# Altium schematic sheet Schematic Prints("All Documents",Physical)
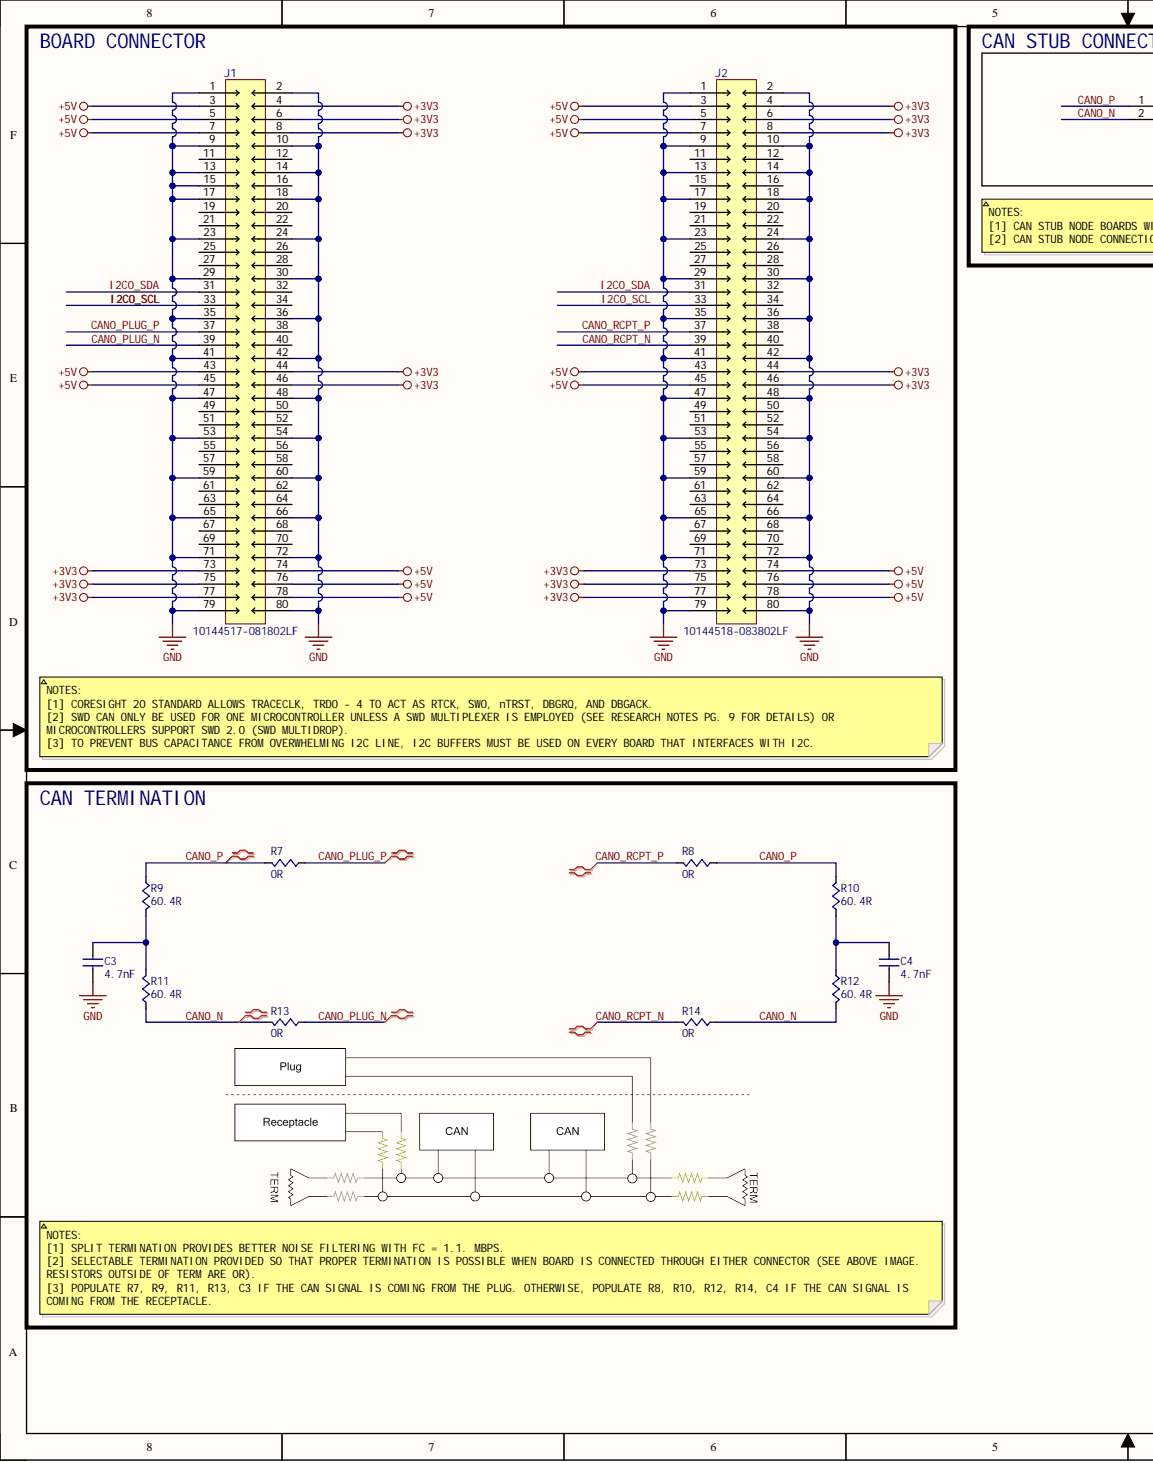
[diagram p0: Schematic Prints("All Documents",Physical), left]
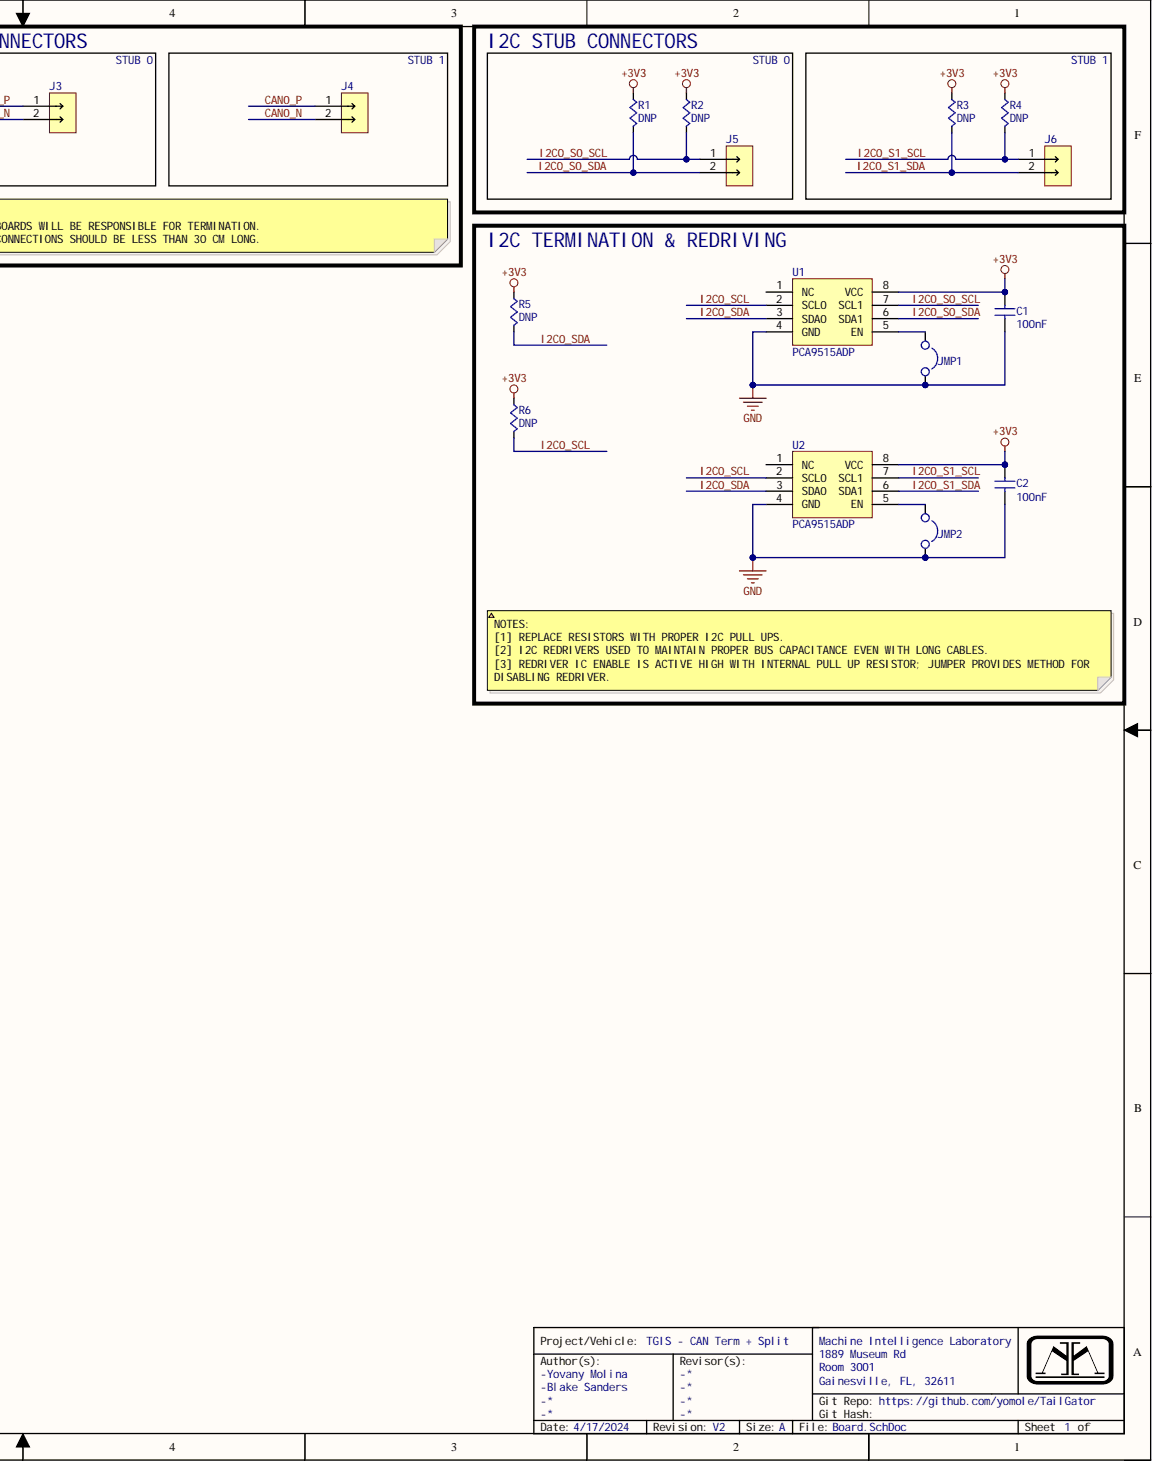
[diagram p1: Schematic Prints("All Documents",Physical), right]

## Parts
C1  100nF  [CAPC0603]
C2  100nF  [CAPC0603]
C3  4.7nF  [CAPC0603]
C4  4.7nF  [CAPC0603]
J1  10144517-081802LF  [AMPHENOL_10144517-081802LF]
J2  10144518-083802LF  [AMPHENOL_10144518-083802LF]
J3  0353630260  [MOLEX-HDR-1X2-SHERLOCK-HORZ]  (value not drawn)
J4  0353630260  [MOLEX-HDR-1X2-SHERLOCK-HORZ]  (value not drawn)
J5  0353630260  [MOLEX-HDR-1X2-SHERLOCK-HORZ]  (value not drawn)
J6  0353630260  [MOLEX-HDR-1X2-SHERLOCK-HORZ]  (value not drawn)
JMP1  2 Pin Header  [2PinJumper]  (value not drawn)  (no BOM)
JMP2  2 Pin Header  [2PinJumper]  (value not drawn)  (no BOM)
R1  DNP  [RES0603]
R2  DNP  [RES0603]
R3  DNP  [RES0603]
R4  DNP  [RES0603]
R5  DNP  [RES0603]
R6  DNP  [RES0603]
R7  0R  [RES0603]
R8  0R  [RES0603]
R9  60.4R  [RES0603]
R10  60.4R  [RES0603]
R11  60.4R  [RES0603]
R12  60.4R  [RES0603]
R13  0R  [RES0603]
R14  0R  [RES0603]
U1  PCA9515ADP  [SOP65P490X110-8N]
U2  PCA9515ADP  [SOP65P490X110-8N]

## Nets
+3V3: C1.2 C2.2 J1.4 J1.6 J1.8 J1.44 J1.46 J1.73 J1.75 J1.77 J2.4 J2.6 J2.8 J2.44 J2.46 J2.73 J2.75 J2.77 R1.2 R2.2 R3.2 R4.2 R5.2 R6.2 U1.8(VCC) U2.8(VCC)
+5V: J1.3 J1.5 J1.7 J1.43 J1.45 J1.74 J1.76 J1.78 J2.3 J2.5 J2.7 J2.43 J2.45 J2.74 J2.76 J2.78
GND: C1.1 C2.1 C3.2 C4.2 J1.1 J1.2 J1.9 J1.10 J1.13 J1.14 J1.15 J1.17 J1.18 J1.23 J1.24 J1.29 J1.30 J1.35 J1.36 J1.41 J1.42 J1.47 J1.48 J1.53 J1.54 J1.59 J1.60 J1.65 J1.66 J1.71 J1.72 J1.79 J1.80 J2.1 J2.2 J2.9 J2.10 J2.13 J2.14 J2.17 J2.18 J2.23 J2.24 J2.29 J2.30 J2.35 J2.36 J2.41 J2.42 J2.47 J2.48 J2.53 J2.54 J2.59 J2.60 J2.65 J2.66 J2.71 J2.72 J2.79 J2.80 JMP1.2 JMP2.2 U1.4(GND) U2.4(GND)
CAN0_N: J3.2 J4.2 R11.2 R12.2 R13.1 R14.2
CAN0_P: J3.1 J4.1 R7.1 R8.2 R9.2 R10.2
CAN0_PLUG_N: J1.39 R13.2
CAN0_PLUG_P: J1.37 R7.2
CAN0_RCPT_N: J2.39 R14.1
CAN0_RCPT_P: J2.37 R8.1
I2C0_S0_SCL: J5.1 R2.1 U1.7(SCL1)
I2C0_S0_SDA: J5.2 R1.1 U1.6(SDA1)
I2C0_S1_SCL: J6.1 R4.1 U2.7(SCL1)
I2C0_S1_SDA: J6.2 R3.1 U2.6(SDA1)
I2C0_SCL: J1.33 J2.33 R6.1 U1.2(SCL0) U2.2(SCL0)
I2C0_SDA: J1.31 J2.31 R5.1 U1.3(SDA0) U2.3(SDA0)
NetC3_1: C3.1 R9.1 R11.1
NetC4_1: C4.1 R10.1 R12.1
NetJ1_11: J1.11
NetJ1_12: J1.12
NetJ1_16: J1.16
NetJ1_19: J1.19
NetJ1_20: J1.20
NetJ1_21: J1.21
NetJ1_22: J1.22
NetJ1_25: J1.25
NetJ1_26: J1.26
NetJ1_27: J1.27
NetJ1_28: J1.28
NetJ1_32: J1.32
NetJ1_34: J1.34
NetJ1_38: J1.38
NetJ1_40: J1.40
NetJ1_49: J1.49
NetJ1_50: J1.50
NetJ1_51: J1.51
NetJ1_52: J1.52
NetJ1_55: J1.55
NetJ1_56: J1.56
NetJ1_57: J1.57
NetJ1_58: J1.58
NetJ1_61: J1.61
NetJ1_62: J1.62
NetJ1_63: J1.63
NetJ1_64: J1.64
NetJ1_67: J1.67
NetJ1_68: J1.68
NetJ1_69: J1.69
NetJ1_70: J1.70
NetJ2_11: J2.11
NetJ2_12: J2.12
NetJ2_15: J2.15
NetJ2_16: J2.16
NetJ2_19: J2.19
NetJ2_20: J2.20
NetJ2_21: J2.21
NetJ2_22: J2.22
NetJ2_25: J2.25
NetJ2_26: J2.26
NetJ2_27: J2.27
NetJ2_28: J2.28
NetJ2_32: J2.32
NetJ2_34: J2.34
NetJ2_38: J2.38
NetJ2_40: J2.40
NetJ2_49: J2.49
NetJ2_50: J2.50
NetJ2_51: J2.51
NetJ2_52: J2.52
NetJ2_55: J2.55
NetJ2_56: J2.56
NetJ2_57: J2.57
NetJ2_58: J2.58
NetJ2_61: J2.61
NetJ2_62: J2.62
NetJ2_63: J2.63
NetJ2_64: J2.64
NetJ2_67: J2.67
NetJ2_68: J2.68
NetJ2_69: J2.69
NetJ2_70: J2.70
NetJMP1_1: JMP1.1 U1.5(EN)
NetJMP2_1: JMP2.1 U2.5(EN)
NetU1_1: U1.1(NC)
NetU2_1: U2.1(NC)

## Library data of the parts
C1 C2 = CC0603KRX7R7BB104: Ceramic Capacitor, Multilayer, Cera; Manufacturer: Yageo; Database Table Name: CAPACITORS; Multilayer: Yes; Rated DC Voltage (URdc): 16V; Temperature Characteristics Code: X7R; Tolerance  (fill in as +-): 10%; Capacitor Type: Ceramic; Case/Package: 0603; Category: Ceramic Capacitors; Component Kind: Standard; ComponentLink1Description: https://datasheet.ciiva.com:443/pdf; ComponentLink1URL: https://datasheet.ciiva.com:443/pdf; ComponentLink2Description: https://datasheet.ciiva.com/11786/0; ComponentLink2URL: https://datasheet.ciiva.com/11786/0; ComponentLink3Description: https://datasheet.ciiva.com/8033/70; ComponentLink3URL: https://datasheet.ciiva.com/8033/70; ComponentLink4Description: https://datasheet.ciiva.com/7978/14; ComponentLink4URL: https://datasheet.ciiva.com/7978/14; ComponentLink5Description: https://datasheet.ciiva.com/28450/1; ComponentLink5URL: https://datasheet.ciiva.com/28450/1; ComponentLink6Description: https://datasheet.ciiva.com/46430/1; ComponentLink6URL: https://datasheet.ciiva.com/46430/1; ComponentLink7Description: https://datasheet.ciiva.com/144/mlc; ComponentLink7URL: https://datasheet.ciiva.com/144/mlc; ComponentLink8Description: https://datasheet.ciiva.com/144/mlc; ComponentLink8URL: https://datasheet.ciiva.com/144/mlc; ComponentLink9Description: https://datasheet.ciiva.com/144/mlc; ComponentLink9URL: https://datasheet.ciiva.com/144/mlc; ComponentLink10Description: https://datasheet.ciiva.com/8033/17; ComponentLink10URL: https://datasheet.ciiva.com/8033/17; ComponentLink11Description: https://datasheet.ciiva.com/13395/u; ComponentLink11URL: https://datasheet.ciiva.com/13395/u; ComponentLink12Description: https://datasheet.ciiva.com/11464/u; ComponentLink12URL: https://datasheet.ciiva.com/11464/u; ComponentLink13Description: https://datasheet.ciiva.com/15165/g; ComponentLink13URL: https://datasheet.ciiva.com/15165/g; ComponentLink14Description: https://datasheet.ciiva.com:443/pdfs/VipMasterIC/IC/YAGO/YAGO-S-A0003557223; ComponentLink14URL: https://datasheet.ciiva.com:443/pdfs/VipMasterIC/IC/YAGO/YAGO-S-A0003557223; ComponentLink15Description: https://datasheet.ciiva.com/11786/0900766b80d84f1d-11786077.pdf?src-supplie; ComponentLink15URL: https://datasheet.ciiva.com/11786/0900766b80d84f1d-11786077.pdf?src-supplie; ComponentLink16Description: https://datasheet.ciiva.com/8033/70765-8033799.pdf?src-supplier=Element14; ComponentLink16URL: https://datasheet.ciiva.com/8033/70765-8033799.pdf?src-supplier=Element14; ComponentLink17Description: https://datasheet.ciiva.com/7978/1489800-7978141.pdf?src-supplier=Element14; ComponentLink17URL: https://datasheet.ciiva.com/7978/1489800-7978141.pdf?src-supplier=Element14; ComponentLink18URL: https://datasheet.ciiva.com/28450/1489800-28450552.pdf?src-supplier=Element; Dielectric Material: Ceramic; Height: 0.8mm; JESD-609 Code: e3; Length: 1.6mm; Library Name: Parts.DbLib; Manufacturer Part Number: CC0603KRX7R7BB104; Max Operating Temperature: 125°C; Min Operating Temperature: -55°C; Mounting Technology: Surface Mount; Package Shape: Rectangular; Package Style: SMTMeter; Packaging: Tape and Reel; Part Number: CC0603KRX7R7BB104; Pins: 2; Pricing 1: 1=0.1, 10=0.042, 50=0.0238, 100=0.0; Reach SVHC Compliant: Yes; RoHS: Yes; RoHS Compliant: Yes; Stock 1: 11347706; Supplier 1: Digikey; Supplier Part Number 1: 311-1088-1-ND; Terminal Finish: Nickel; Termination: Wraparound; Tolerance (fill in as +-): 10%; Value: 100nF; Width: 0.8mm
C3 C4 = CC0603JPX7R9BB472: Ceramic Capacitor, Multilayer, Cera; Manufacturer: Yageo; Database Table Name: CAPACITORS; Multilayer: Yes; Rated DC Voltage (URdc): 50V; Temperature Characteristics Code: X7R; Tolerance  (fill in as +-): 5%; Capacitor Type: Ceramic; Case/Package: 0603; Category: Ceramic Capacitors; Component Kind: Standard; ComponentLink1Description: https://datasheet.ciiva.com:443/pdf; ComponentLink1URL: https://datasheet.ciiva.com:443/pdf; ComponentLink2Description: http://www.mouser.com/ds/2/447/Yage; ComponentLink2URL: http://www.mouser.com/ds/2/447/Yage; Dielectric Material: Ceramic; Height: 0.8mm; JESD-609 Code: e3; Length: 1.6mm; Library Name: Parts.DbLib; Manufacturer Part Number: CC0603JPX7R9BB472; Max Operating Temperature: 125°C; Min Operating Temperature: -55°C; Mounting Technology: Surface Mount; Package Shape: Rectangular; Package Style: SMTMeter; Packaging: Tape and Reel; Part Number: CC0603JPX7R9BB472; Pins: 2; Pricing 1: 1=0.1, 10=0.069, 50=0.0398, 100=0.0; Reach SVHC Compliant: Yes; RoHS: Yes; RoHS Compliant: Yes; Stock 1: 59880; Supplier 1: Digikey; Supplier Part Number 1: 13-CC0603JPX7R9BB472CT-ND; Terminal Finish: Nickel; Termination: Wraparound; Tolerance (fill in as +-): 5%; Value: 4.7nF; Width: 0.8mm
J1 = 10144517-081802LF: CONN RCPT 1 80POS SMD GOLD; System: Connector; Component Kind: Standard; Supplier 1: Digikey; Supplier Part Number 1: 609-5437-1-ND; Library Name: TGIS.IntLib
J2 = 10144518-083802LF: CONN PLUG 3 80POS SMD GOLD; System: Connector; Component Kind: Standard; Supplier 1: Digikey; Supplier Part Number 1: 609-5455-1-ND; Library Name: TGIS.IntLib
J3-J6 = SHERLOCK-1x2-RA: Header, Molex, 2mm Pitch Sherlock Header, Single Row, Horizontal, 2 Circuits, Length 3.3mm,  0353630260; Manufacturer: Molex Inc; Component Kind: Standard; Library Name: Connectors.SchLib; Manufacturer Part Number: 0353630260; Supplier 1: Digi-Key; Supplier Part Number 1: WM18931-ND
JMP1 JMP2 = Jumper_2Pin: 2 Position Jumper; Library Name: Misc.SchLib
R1-R6 = Resistor_DNP: (DO NOT PLACE) Resistor; Component Kind: Standard; Library Name: DNP.SchLib
R7 R8 R13 R14 = RC0603FR-070RL: Fixed Resistor, Metal Glaze/thick F; Database Table Name: RESISTORS; Power: 100mW; Rated Temperature: 70°C; Case/Package: 0603; Category: Chip SMD Resistors; Component Kind: Standard; ComponentLink1Description: https://datasheet.ciiva.com:443/pdf; ComponentLink1URL: https://datasheet.ciiva.com:443/pdf; ComponentLink2Description: https://datasheet.ciiva.com/11808/0; ComponentLink2URL: https://datasheet.ciiva.com/11808/0; ComponentLink3Description: https://datasheet.ciiva.com/5684/44; ComponentLink3URL: https://datasheet.ciiva.com/5684/44; ComponentLink4Description: https://datasheet.ciiva.com/7983/44; ComponentLink4URL: https://datasheet.ciiva.com/7983/44; ComponentLink5Description: https://datasheet.ciiva.com/22643/1; ComponentLink5URL: https://datasheet.ciiva.com/22643/1; ComponentLink6Description: https://datasheet.ciiva.com/46382/4; ComponentLink6URL: https://datasheet.ciiva.com/46382/4; ComponentLink7Description: https://datasheet.ciiva.com/29721/p; ComponentLink7URL: https://datasheet.ciiva.com/29721/p; ComponentLink8Description: https://datasheet.ciiva.com/29721/p; ComponentLink8URL: https://datasheet.ciiva.com/29721/p; ComponentLink9Description: https://datasheet.ciiva.com/5558/rc; ComponentLink9URL: https://datasheet.ciiva.com/5558/rc; JESD-609 Code: e3; Library Name: Parts.DbLib; Max Operating Temperature: 155°C; Min Operating Temperature: -55°C; Mounting Technology: Surface Mount; Package Shape: Rectangular; Packaging: Tape and Reel; Part Number: RC0603FR-070RL; Pins: 2; Pricing 1: 5000=0.0015, 50000=0.0014, 125000=0; Reach SVHC Compliant: Yes; Resistor Type: FIXED RESISTOR; RoHS Compliant: Yes; Stock 1: 2895000; Technology: METAL GLAZE/THICK FILM; Terminal Finish: Nickel; Termination: Wraparound; Value: 0R
R9-R12 = RC0603FR-0760R4L: Fixed Resistor, Metal Glaze/thick F; Manufacturer: Yageo; Additional Feature: LASER TRIMMABLE; Database Table Name: RESISTORS; Package Height: 0.45mm; Package Length: 1.6mm; Package Width: 0.8mm; Power: 100mW; Rated Temperature: 70°C; Temperature Coefficient: 100ppm/°C; Tolerance: 1%; Working Voltage: 75V; Case/Package: 0603; Category: Chip SMD Resistors; Component Kind: Standard; ComponentLink1Description: https://datasheet.ciiva.com:443/pdf; ComponentLink1URL: https://datasheet.ciiva.com:443/pdf; ComponentLink2Description: https://datasheet.ciiva.com/12558/r; ComponentLink2URL: https://datasheet.ciiva.com/12558/r; Construction: Chip; JESD-609 Code: e3; Library Name: Parts.DbLib; Manufacturer Part Number: RC0603FR-0760R4L; Max Operating Temperature: 155°C; Min Operating Temperature: -55°C; Mounting Technology: Surface Mount; Package Shape: Rectangular; Package Style: SMTMeter; Packaging: Tape and Reel; Part Number: RC0603FR-0760R4L; Pins: 2; Pricing 1: 1=0.1, 10=0.019, 50=0.0112, 100=0.0; Reach SVHC Compliant: Yes; Resistor Type: FIXED RESISTOR; RoHS: Yes; RoHS Compliant: Yes; Stock 1: 181136; Supplier 1: Digikey; Supplier Part Number 1: 311-60.4HRCT-ND; Technology: METAL GLAZE/THICK FILM; Terminal Finish: Nickel; Termination: Wraparound; Value: 60.4R
U1 U2 = PCA9515ADP: IC REDRIVER I2C 2CH 8TSSOP; Component Kind: Standard; Supplier 1: Digikey; Supplier Part Number 1: 568-1032-1-ND; Library Name: TGIS.IntLib
